# Altium schematic sheet lt_power_jtag.SchDoc(lt_power_jtag)
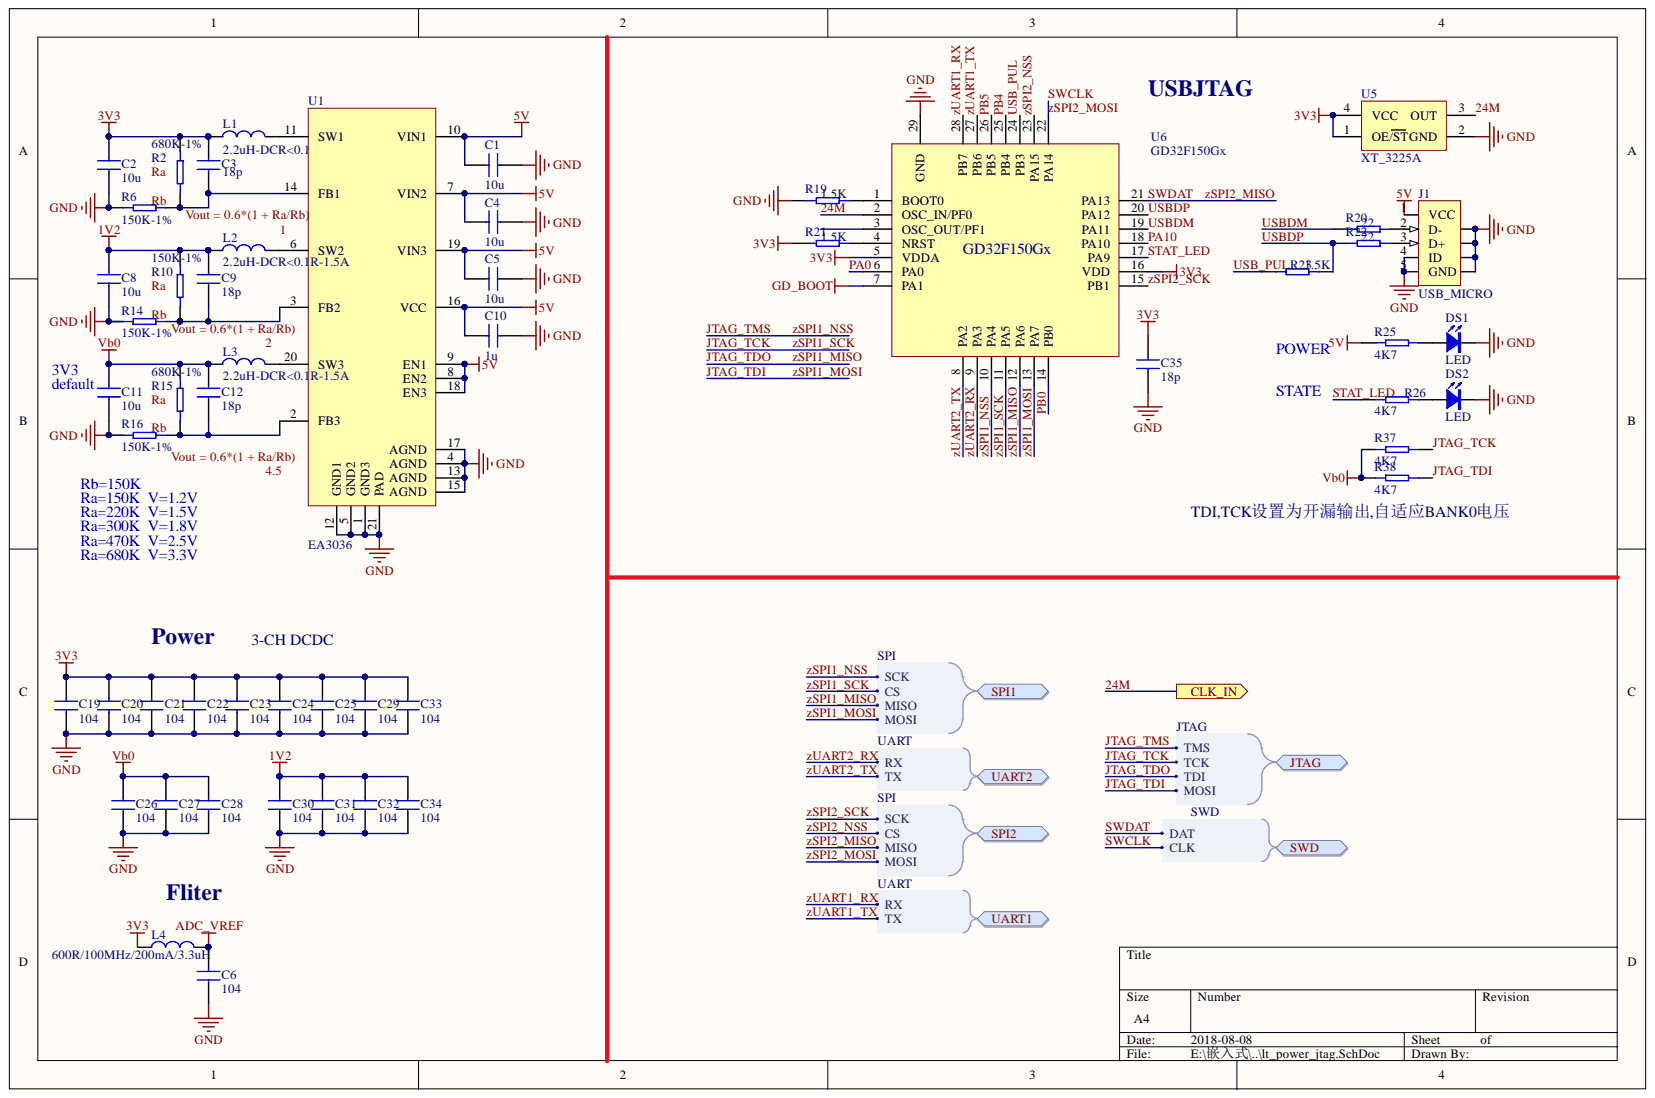
[diagram p0: lt_power_jtag.SchDoc]

## Parts
C1  10u  [0603C]
C2  10u  [0603C]
C3  18p  [0402C]
C4  10u  [0603C]
C5  10u  [0603C]
C6  104  [0402C]
C8  10u  [0603C]
C9  18p  [0402C]
C10  1u  [0402C]
C11  10u  [0603C]
C12  18p  [0402C]
C19  104  [0402C]
C20  104  [0402C]
C21  104  [0402C]
C22  104  [0402C]
C23  104  [0402C]
C24  104  [0402C]
C25  104  [0402C]
C26  104  [0402C]
C27  104  [0402C]
C28  104  [0402C]
C29  104  [0402C]
C30  104  [0402C]
C31  104  [0402C]
C32  104  [0402C]
C33  104  [0402C]
C34  104  [0402C]
C35  18p  [0402C]
DS1  LED  [0603D_R]
DS2  LED  [0603D_R]
J1  USB_MICRO  [USB_MICRO_insert]
L1  2.2uH-DCR<0.1R-1.5A  [0806L]
L2  2.2uH-DCR<0.1R-1.5A  [0806L]
L3  2.2uH-DCR<0.1R-1.5A  [0806L]
L4  600R/100MHz/200mA/3.3uH  [0603R]
R2  680K-1%  [0402R]
R6  150K-1%  [0402R]
R10  150K-1%  [0402R]
R14  150K-1%  [0402R]
R15  680K-1%  [0402R]
R16  150K-1%  [0402R]
R19  1.5K  [0402R]  (value not drawn)
R20  22  [0402R]  (value not drawn)
R21  1.5K  [0402R]  (value not drawn)
R22  22  [0402R]  (value not drawn)
R23  1.5K  [0402R]
R25  4K7  [0402R]
R26  4K7  [0402R]
R37  4K7  [0402R]
R38  4K7  [0402R]
U1  EA3036  [QFN20-3x3]
U5  XT_3225A  [SMD3225]
U6  GD32F150Gx  [QFN28]

## Ports
CLK_IN, JTAG, JTAG.MOSI, JTAG.TCK, JTAG.TDI, JTAG.TMS, SPI1, SPI1.CS, SPI1.MISO, SPI1.MOSI, SPI1.SCK, SPI2, SPI2.CS, SPI2.MISO, SPI2.MOSI, SPI2.SCK, SWD, SWD.CLK, SWD.DAT, UART1, UART1.RX, UART1.TX, UART2, UART2.RX, UART2.TX

## Nets
1V2: C8.2 C9.2 C30.1 C31.1 C32.1 C34.1 L2.1 R10.1
3V3: C2.2 C3.2 C19.1 C20.1 C21.1 C22.1 C23.1 C24.1 C25.1 C29.1 C33.1 C35.2 L1.2 L4.2 R2.1 R21.2 U5.1(OE/ST) U5.4(VCC) U6.5(VDDA) U6.16(VDD)
5V: C1.2 C4.2 C5.1 C10.1 J1.1(VCC) R25.2 U1.7(VIN2) U1.8(EN2) U1.9(EN1) U1.10(VIN1) U1.16(VCC) U1.18(EN3) U1.19(VIN3)
ADC_VREF: C6.1 L4.1
GD_BOOT: U6.7(PA1)
GND: C1.1 C2.1 C4.1 C5.2 C6.2 C8.1 C10.2 C11.1 C19.2 C20.2 C21.2 C22.2 C23.2 C24.2 C25.2 C26.2 C27.2 C28.2 C29.2 C30.2 C31.2 C32.2 C33.2 C34.2 C35.1 DS1.K DS2.K J1.4(ID) J1.5 J1.6(GND) J1.7 J1.8 J1.9 R6.2 R14.1 R16.1 R19.2 U1.1(GND3) U1.4(AGND) U1.5(GND2) U1.12(GND1) U1.13(AGND) U1.15(AGND) U1.17(AGND) U1.21(PAD) U5.2(GND) U6.29(GND)
VB0: C11.2 C12.2 C26.1 C27.1 C28.1 L3.1 R15.1 R37.1 R38.1
24M: U5.3(OUT) U6.2(OSC_IN/PF0) ; port CLK_IN
JTAG_TCK: R37.2 U6.11(PA5) ; port JTAG, port SPI1
JTAG_TDI: R38.2 U6.13(PA7) ; port JTAG, port SPI1
JTAG_TDO: U6.12(PA6) ; port JTAG, port SPI1
JTAG_TMS: U6.10(PA4) ; port JTAG, port SPI1
PA0: U6.6(PA0)
PA10: U6.18(PA10)
PB0: U6.14(PB0)
PB4: U6.25(PB4)
PB5: U6.26(PB5)
STAT_LED: R26.2 U6.17(PA9)
SWCLK: U6.22(PA14) ; port SPI2, port SWD
SWDAT: U6.21(PA13) ; port SPI2, port SWD
USB_PUL: R23.2 U6.24(PB3)
USBDM: R20.2 U6.19(PA11)
USBDP: R22.2 R23.1 U6.20(PA12)
ZSPI2_NSS: U6.23(PA15) ; port SPI2
ZSPI2_SCK: U6.15(PB1) ; port SPI2
ZUART1_RX: U6.28(PB7) ; port UART1
ZUART1_TX: U6.27(PB6) ; port UART1
ZUART2_RX: U6.9(PA3) ; port UART2
ZUART2_TX: U6.8(PA2) ; port UART2
NetC3_1: C3.1 R2.2 R6.1 U1.14(FB1)
NetC9_1: C9.1 R10.2 R14.2 U1.3(FB2)
NetC12_1: C12.1 R15.2 R16.2 U1.2(FB3)
NetDS1_A: DS1.A R25.1
NetDS2_A: DS2.A R26.1
NetJ1_2: J1.2(D-) R20.1
NetJ1_3: J1.3(D+) R22.1
NetL1_1: L1.1 U1.11(SW1)
NetL2_2: L2.2 U1.6(SW2)
NetL3_2: L3.2 U1.20(SW3)
NetR19_1: R19.1 U6.1(BOOT0)
NetR21_1: R21.1 U6.4(NRST)

Not connected: U6 3(OSC_OUT/PF1)

## Library data of the parts
C1-C6 C8-C12 C19-C35 = CAP: SMD-CAP; Component Kind: Standard; Library Name: RCDQLY.SCHLIB
L1-L4 = L1: 电感; Component Kind: Standard; Library Name: RCDQLY.SCHLIB
R2 R6 R10 R14-R16 R19-R23 R25 R26 R37 R38 = R: Component Kind: Standard; Library Name: RCDQLY.SCHLIB
DS1 DS2 = LED: Component Kind: Standard; Library Name: RCDQLY.SCHLIB
J1 = USB_MICRO: Component Kind: Standard; Library Name: jointbar.SCHLIB
U1 = EA3036: Component Kind: Standard; Library Name: IC.SCHLIB
U5 = XT_3225A: Component Kind: Standard; Library Name: RCDQLY.SCHLIB
U6 = GD32F150Gx: Component Kind: Standard; Library Name: IC.SCHLIB
